# Altium schematic sheet DriverBoard.SchDoc
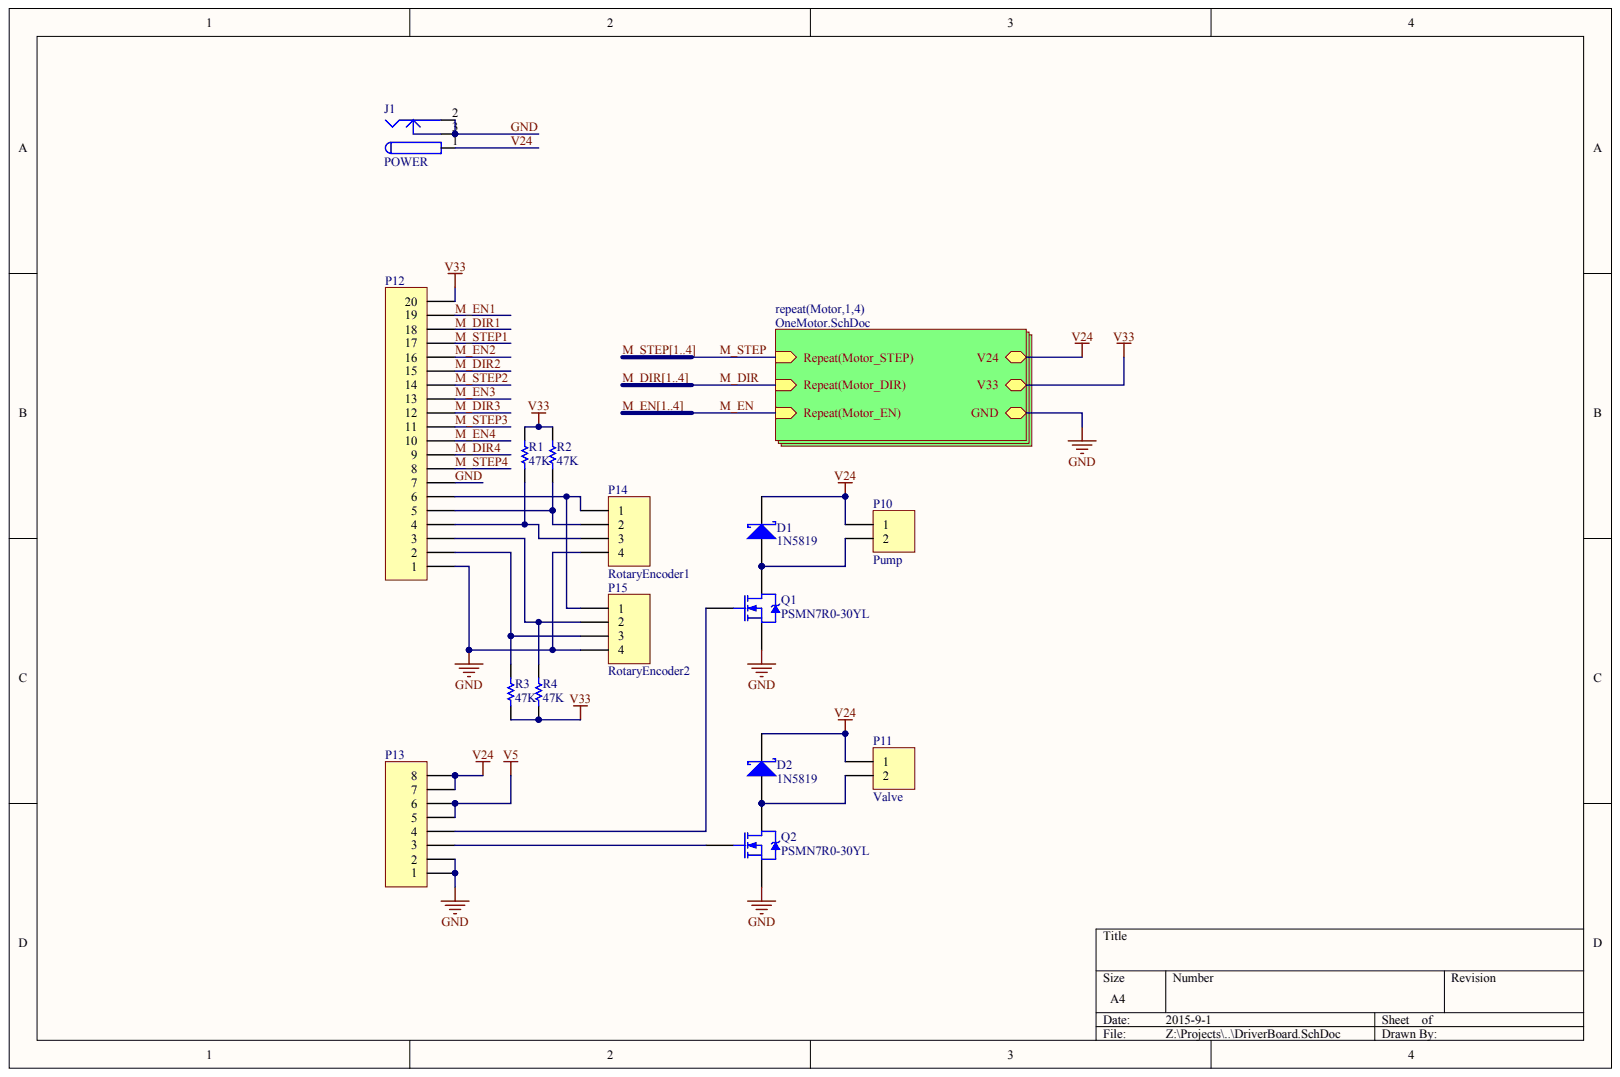
[diagram p0: DriverBoard.SchDoc]

## Parts
D1  1N5819
D2  1N5819
J1  POWER
P10  Pump
P11  Valve
P12  Header 20  (value not drawn)
P13  Header 8  (value not drawn)
P14  RotaryEncoder1
P15  RotaryEncoder2
Q1  PSMN7R0-30YL
Q2  PSMN7R0-30YL
R1  value 47K  (hidden comment: Res Semi)
R2  value 47K  (hidden comment: Res Semi)
R3  value 47K  (hidden comment: Res Semi)
R4  value 47K  (hidden comment: Res Semi)

## Nets
V5: P13.5 P13.6
V33: P12.20 R1.2 R2.2 R3.1 R4.1
GND: J1.2 J1.3 P12.1 P12.7 P13.1 P13.2 P14.4 P15.4 Q1.3 Q2.3
M_DIR1: P12.18  [also labelled M_DIR]
M_DIR2: P12.15  [also labelled M_DIR]
M_DIR3: P12.12  [also labelled M_DIR]
M_DIR4: P12.9  [also labelled M_DIR]
M_EN1: P12.19  [also labelled M_EN]
M_EN2: P12.16  [also labelled M_EN]
M_EN3: P12.13  [also labelled M_EN]
M_EN4: P12.10  [also labelled M_EN]
M_STEP1: P12.17  [also labelled M_STEP]
M_STEP2: P12.14  [also labelled M_STEP]
M_STEP3: P12.11  [also labelled M_STEP]
M_STEP4: P12.8  [also labelled M_STEP]
V24: D1.2 D2.2 J1.1 P10.1 P11.1 P13.7 P13.8
NetD1_1: D1.1 P10.2 Q1.2
NetD2_1: D2.1 P11.2 Q2.2
NetP12_2: P12.2 P15.3 R3.2
NetP12_3: P12.3 P15.2 R4.2
NetP12_4: P12.4 P14.3 R1.1
NetP12_5: P12.5 P14.2 R2.1
NetP12_6: P12.6 P14.1 P15.1
NetP13_3: P13.3 Q2.1
NetP13_4: P13.4 Q1.1

## Library data of the parts
Q1 Q2 = (no symbol name): Note: Pin 2 is electrically connected to pin 4.; Published: 8-Jun-2000; LatestRevisionDate: 17-Jul-2002; LatestRevisionNote: Re-released for DXP Platform.; DatasheetDocument: PD-9.814; PackageReference: TO-220AB; Code_JEDEC: TO-220-AB; ComponentLink1Description: Manufacturer Link; ComponentLink1URL: http://www.irf.com/; Publisher: Altium Limited
D1 D2 J1 P10-P15 R1-R4 = (no symbol name)
  D1 D2: Published: 8-Jun-2000; LatestRevisionDate: 17-Jul-2002; LatestRevisionNote: Re-released for DXP Platform.; PackageReference: SMB; Code_JEDEC: DO-214-AA
  P10-P15: LatestRevisionDate: 17-Jul-2002; LatestRevisionNote: Re-released for DXP Platform.
  R1-R4: Published: 8-Jun-2000; LatestRevisionDate: 17-Jul-2002; LatestRevisionNote: Re-released for DXP Platform.; PackageReference: AXIAL-0.5
  D1 D2 P10-P15 R1-R4: Publisher: Altium Limited
